# Altium schematic sheet Schematic.SchDoc(Schematic)
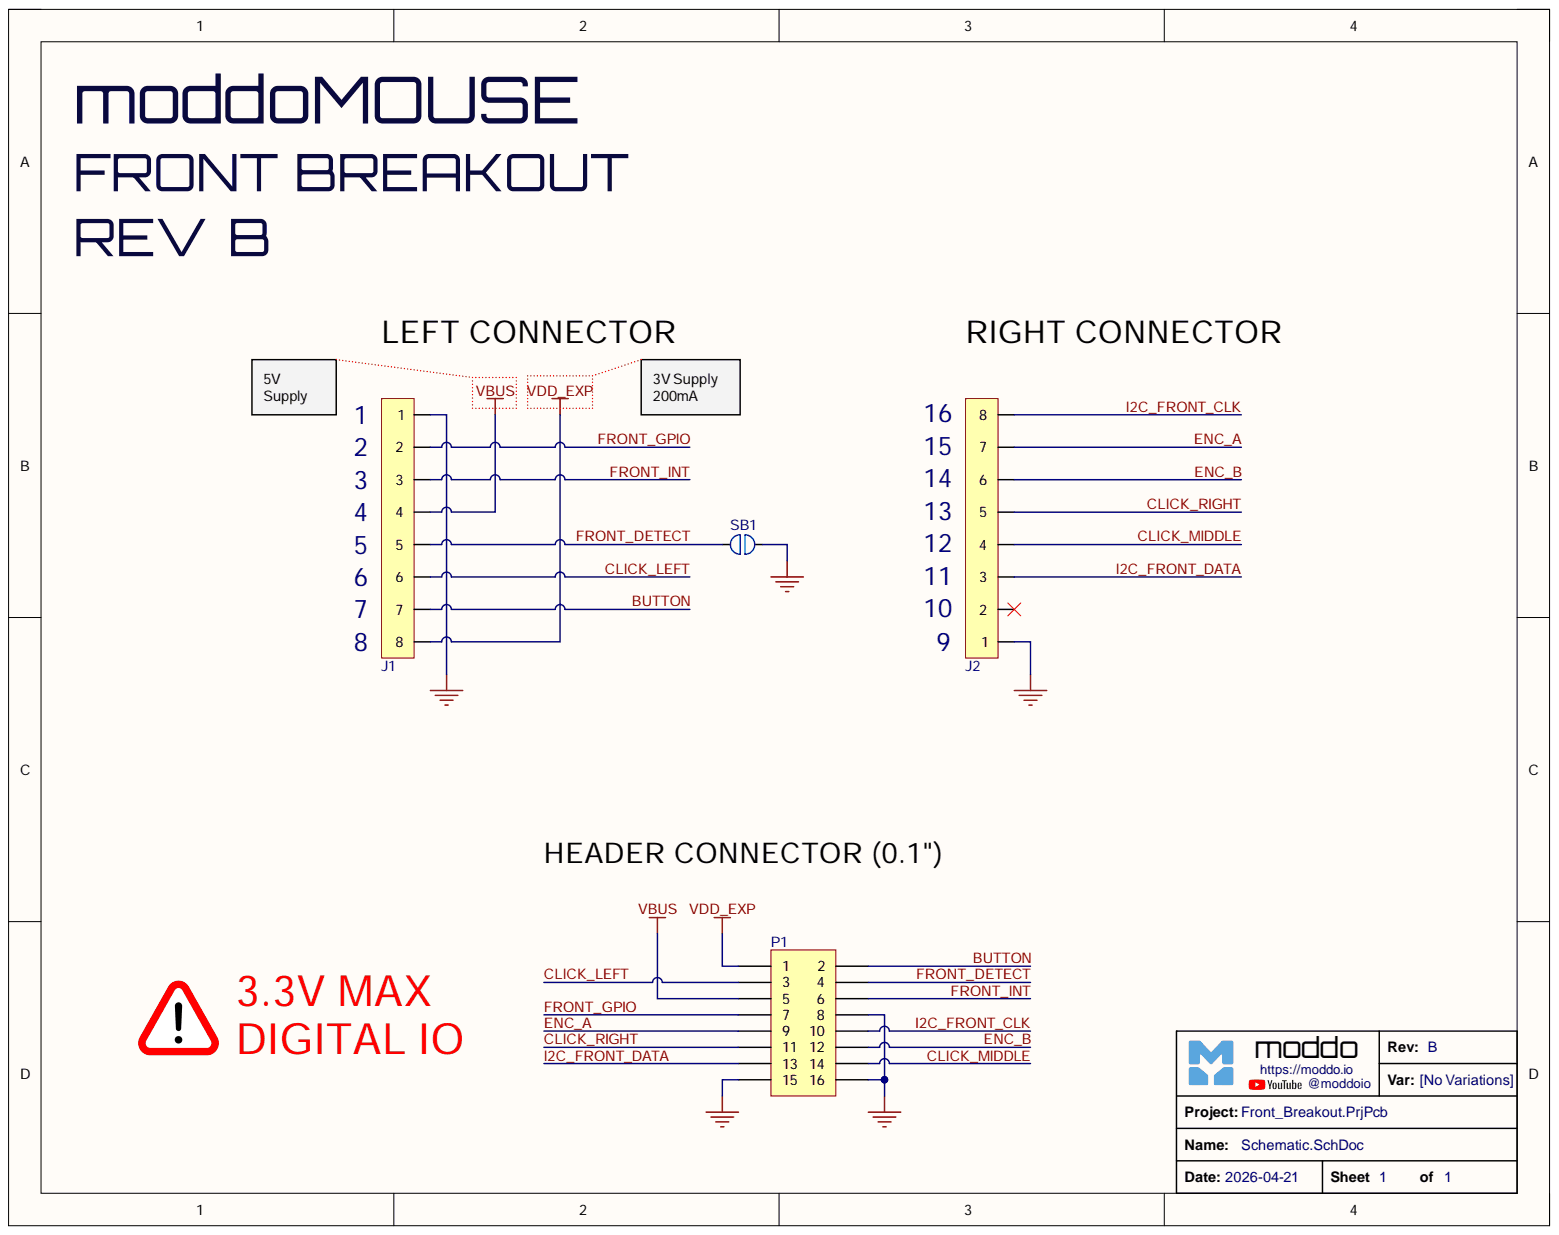
[diagram p0: Schematic.SchDoc]

## Parts
J1  1.27mm_8pins  [1.27mm_8pins_MaleHeader]  (value not drawn)
J2  1.27mm_8pins  [1.27mm_8pins_MaleHeader]  (value not drawn)
P1  Header 8X2  [HEADER_2_54_F_2X8]  (value not drawn)
SB1  Solderbridge  [Solderbridge]  (value not drawn)  (no BOM)

## Nets
GND: J1.1 J2.1 P1.8 P1.15 P1.16 SB1.1
VBUS: J1.4 P1.5
VDD_EXP: J1.8 P1.1
BUTTON: J1.7 P1.2
CLICK_LEFT: J1.6 P1.3
CLICK_MIDDLE: J2.4 P1.14
CLICK_RIGHT: J2.5 P1.11
ENC_A: J2.7 P1.9
ENC_B: J2.6 P1.12
FRONT_DETECT: J1.5 P1.4 SB1.2
FRONT_GPIO: J1.2 P1.7
FRONT_INT: J1.3 P1.6
I2C_FRONT_CLK: J2.8 P1.10
I2C_FRONT_DATA: J2.3 P1.13

Not connected: J2 2

## Library data of the parts
P1 = Header 8X2: Header, 8-Pin, Dual row; Manufacturer: Adam Tech; Manufacturer Part Number: RS2-16-G; Component Kind: Standard; LatestRevisionDate: 17-Jul-2002; LatestRevisionNote: Re-released for DXP Platform.; Library Name: Miscellaneous Connectors.IntLib; Signal Integrity: Connector; Publisher: Altium Limited
SB1 = SOLDERBRIDGE: Artikkelnr Noca: N.A.; Artikkelnr Simpro: N.A.; Description: Solderbridge; Footprint Doc: Solderbridge; LatestRevisionDate: 2009-12-14; Library Name: MySchematics.SchLib; Part Number: Solderbridge; Pin Count: 2; Published: 2009-12-14; Value: Solderbridge; Publisher: Nordic Semiconductor
J1 J2 = 1.27mm_8pins: Component Kind: Standard; Library Name: MySchematics.SchLib
